# Altium schematic sheet Sheet1.SchDoc
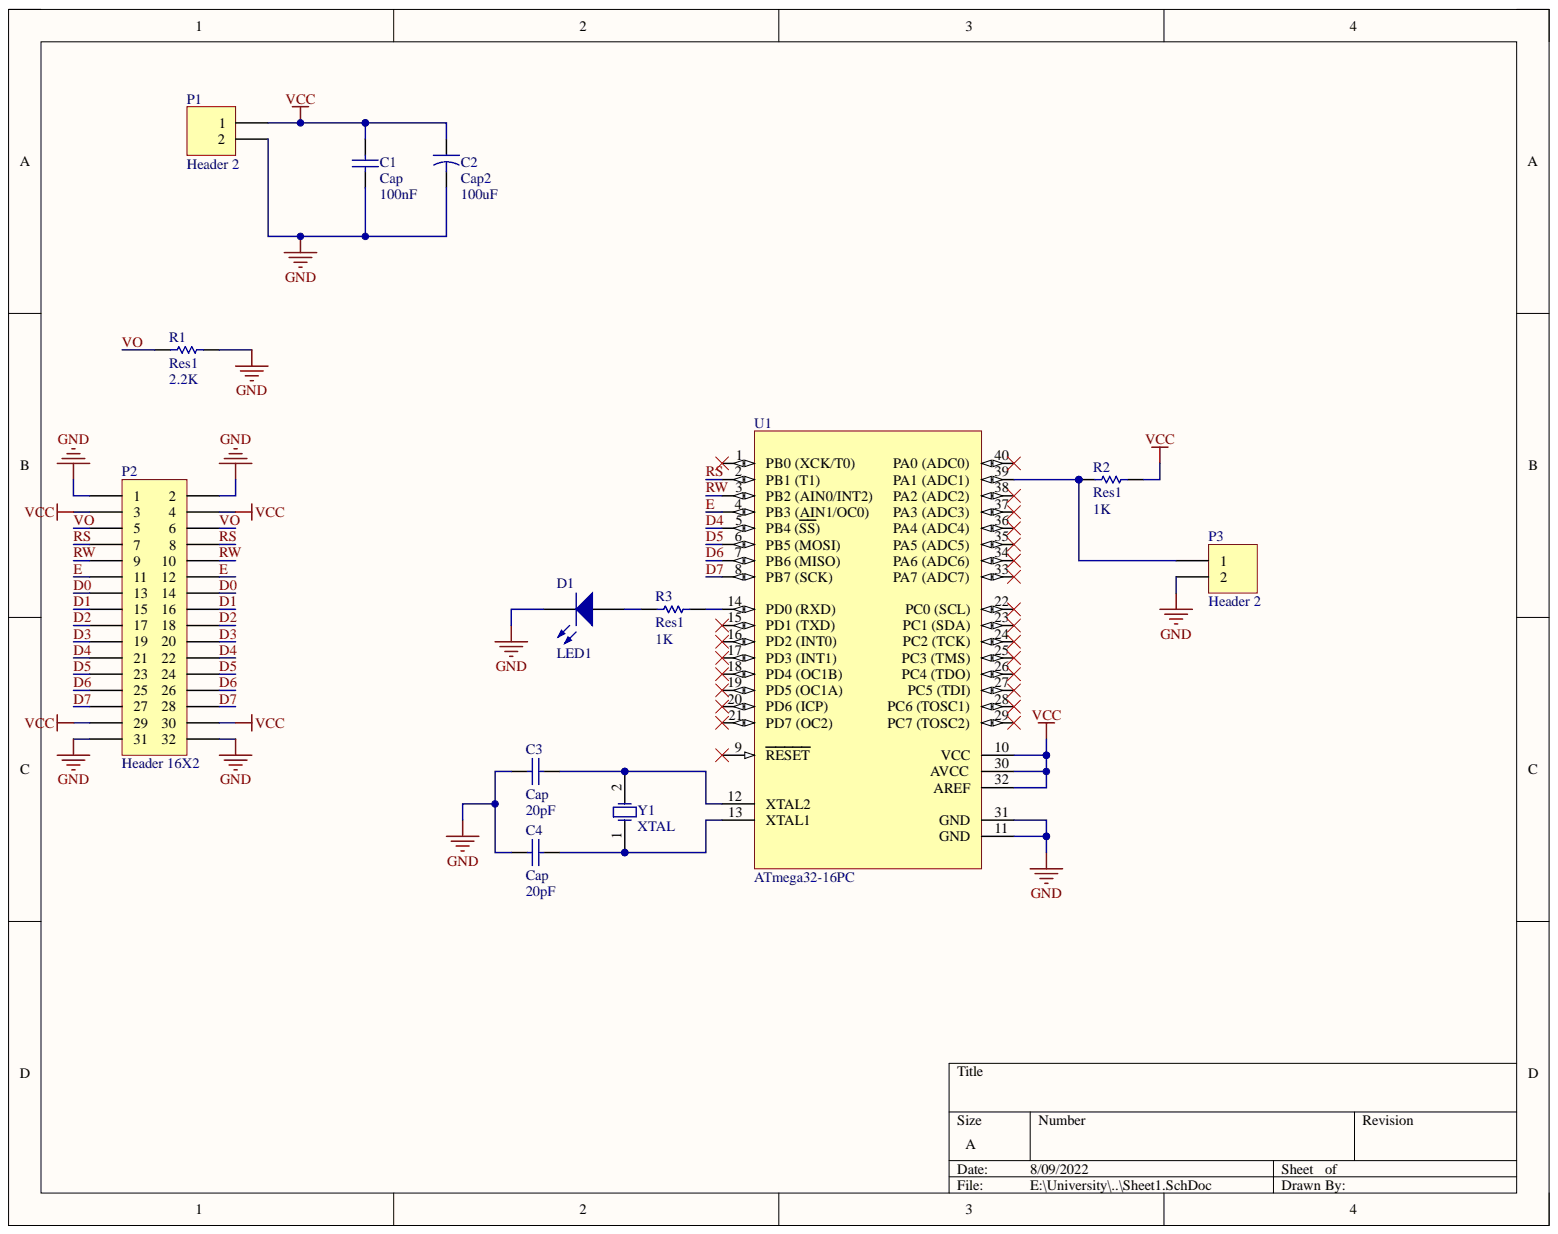
[diagram p0: Sheet1.SchDoc]

## Parts
C1  Cap  value 100nF  [RAD-0.3]
C2  Cap2  value 100uF  [CAPR5-4X5]
C3  Cap  value 20pF  [RAD-0.3]
C4  Cap  value 20pF  [RAD-0.3]
D1  LED1  [LED-1]
P1  Header 2  [HDR1X2]
P2  Header 16X2  [HDR2X16]
P3  Header 2  [HDR1X2]
R1  Res1  value 2.2K  [AXIAL-0.3]
R2  Res1  value 1K  [AXIAL-0.3]
R3  Res1  value 1K  [AXIAL-0.3]
U1  ATmega32-16PC  [40P6]
Y1  XTAL  [BCY-W2/D3.1]

## Nets
GND: C1.1 C2.2 C3.1 C4.1 D1.2 P1.2 P2.1 P2.2 P2.31 P2.32 P3.2 R1.2 U1.11(GND) U1.31(GND)
VCC: C1.2 C2.1 P1.1 P2.3 P2.4 P2.29 P2.30 R2.2 U1.10(VCC) U1.30(AVCC) U1.32(AREF)
D0: P2.13 P2.14
D1: P2.15 P2.16
D2: P2.17 P2.18
D3: P2.19 P2.20
D4: P2.21 P2.22 U1.5(PB4_(SS))
D5: P2.23 P2.24 U1.6(PB5_(MOSI))
D6: P2.25 P2.26 U1.7(PB6_(MISO))
D7: P2.27 P2.28 U1.8(PB7_(SCK))
E: P2.11 P2.12 U1.4(PB3_(AIN1/OC0))
RS: P2.7 P2.8 U1.2(PB1_(T1))
RW: P2.9 P2.10 U1.3(PB2_(AIN0/INT2))
VO: P2.5 P2.6 R1.1
NetC3_2: C3.2 U1.12(XTAL2) Y1.2
NetC4_2: C4.2 U1.13(XTAL1) Y1.1
NetD1_1: D1.1 R3.1
NetP3_1: P3.1 R2.1 U1.39(PA1_(ADC1))
NetR3_2: R3.2 U1.14(PD0_(RXD))

Not connected: U1 1(PB0_(XCK/T0)) 9(RESET) 15(PD1_(TXD)) 16(PD2_(INT0)) 17(PD3_(INT1)) 18(PD4_(OC1B)) 19(PD5_(OC1A)) 20(PD6_(ICP)) 21(PD7_(OC2)) 22(PC0_(SCL)) 23(PC1_(SDA)) 24(PC2_(TCK)) 25(PC3_(TMS)) 26(PC4_(TDO)) 27(PC5_(TDI)) 28(PC6_(TOSC1)) 29(PC7_(TOSC2)) 33(PA7_(ADC7)) 34(PA6_(ADC6)) 35(PA5_(ADC5)) 36(PA4_(ADC4)) 37(PA3_(ADC3)) 38(PA2_(ADC2)) 40(PA0_(ADC0))

## Library data of the parts
C1 C4 = Cap: Capacitor; Component Kind: Standard; LatestRevisionDate: 17-Jul-2002; LatestRevisionNote: Re-released for DXP Platform.; Library Name: Miscellaneous Devices.IntLib; PackageReference: RAD-0.3; Published: 8-Jun-2000; Signal Integrity: Cap; Simulation: CAP; Publisher: Altium Limited
C2 = Cap2: Capacitor; Component Kind: Standard; Library Name: Miscellaneous Devices.IntLib; PackageReference: CAPR5-4X5; Published: 23-Sep-2002; Signal Integrity: Cap; Simulation: CAP; Publisher: Altium Limited
C3 = Cap: Capacitor; Value: 20pF; Component Kind: Standard; LatestRevisionDate: 17-Jul-2002; LatestRevisionNote: Re-released for DXP Platform.; Library Name: Miscellaneous Devices.IntLib; PackageReference: RAD-0.3; Published: 8-Jun-2000; Signal Integrity: Cap; Simulation: CAP; Publisher: Altium Limited
D1 = LED1: Typical RED GaAs LED; Component Kind: Standard; LatestRevisionDate: 08-Jul-2005; LatestRevisionNote: Mech Layer 1 removed.; Library Name: Miscellaneous Devices.IntLib; PackageReference: LED-1; Published: 8-Jun-2000; Simulation: LED1; Publisher: Altium Limited
P1 P3 = Header 2: Header, 2-Pin; Component Kind: Standard; LatestRevisionDate: 17-Jul-2002; LatestRevisionNote: Re-released for DXP Platform.; Library Name: Miscellaneous Connectors.IntLib; Signal Integrity: Connector; Publisher: Altium Limited
P2 = Header 16X2: Header, 16-Pin, Dual row; Component Kind: Standard; LatestRevisionDate: 17-Jul-2002; LatestRevisionNote: Re-released for DXP Platform.; Library Name: Miscellaneous Connectors.IntLib; Signal Integrity: Connector; Publisher: Altium Limited
R1-R3 = Res1: Resistor; Component Kind: Standard; LatestRevisionDate: 17-Jul-2002; LatestRevisionNote: Re-released for DXP Platform.; Library Name: Miscellaneous Devices.IntLib; PackageReference: AXIAL-0.3; Published: 8-Jun-2000; Signal Integrity: Res; Simulation: RESISTOR; Publisher: Altium Limited
U1 = ATmega32-16PC: 8-Bit AVR Microcontroller with 32K Bytes of In-System Programmable Flash Memory; Component Kind: Standard; ComponentLink1Description: Manufacturer Link; ComponentLink1URL: http://www.atmel.com; ComponentLink2Description: Datasheet; ComponentLink2URL: http://www.atmel.com/dyn/resources/prod_documents/doc2503.pdf; DatasheetVersion: 2503C-AVR-10/02; LatestRevisionDate: 04-Mar-2005; LatestRevisionNote: Stylized 3D Model Added.; Library Name: Atmel Microcontroller 8-Bit AVR.IntLib; PackageDescription: DIP; 40 Leads; Row Spacing 15.24 mm; Pitch 2.54 mm; PackageReference: 40P6; PackageVersion: Dec-1999; PCB3D: 40P6; Published: 17-Sep-2003; Publisher: Altium Limited
Y1 = XTAL: Crystal Oscillator; Component Kind: Standard; LatestRevisionDate: 17-Jul-2002; LatestRevisionNote: Re-released for DXP Platform.; Library Name: Miscellaneous Devices.IntLib; PackageReference: R38; Published: 8-Jun-2000; Simulation: XTAL; Publisher: Altium Limited
